# Altium schematic sheet STM32F429IGT6-V1.0.SchDoc(STM32F429IGT6-V1.0)
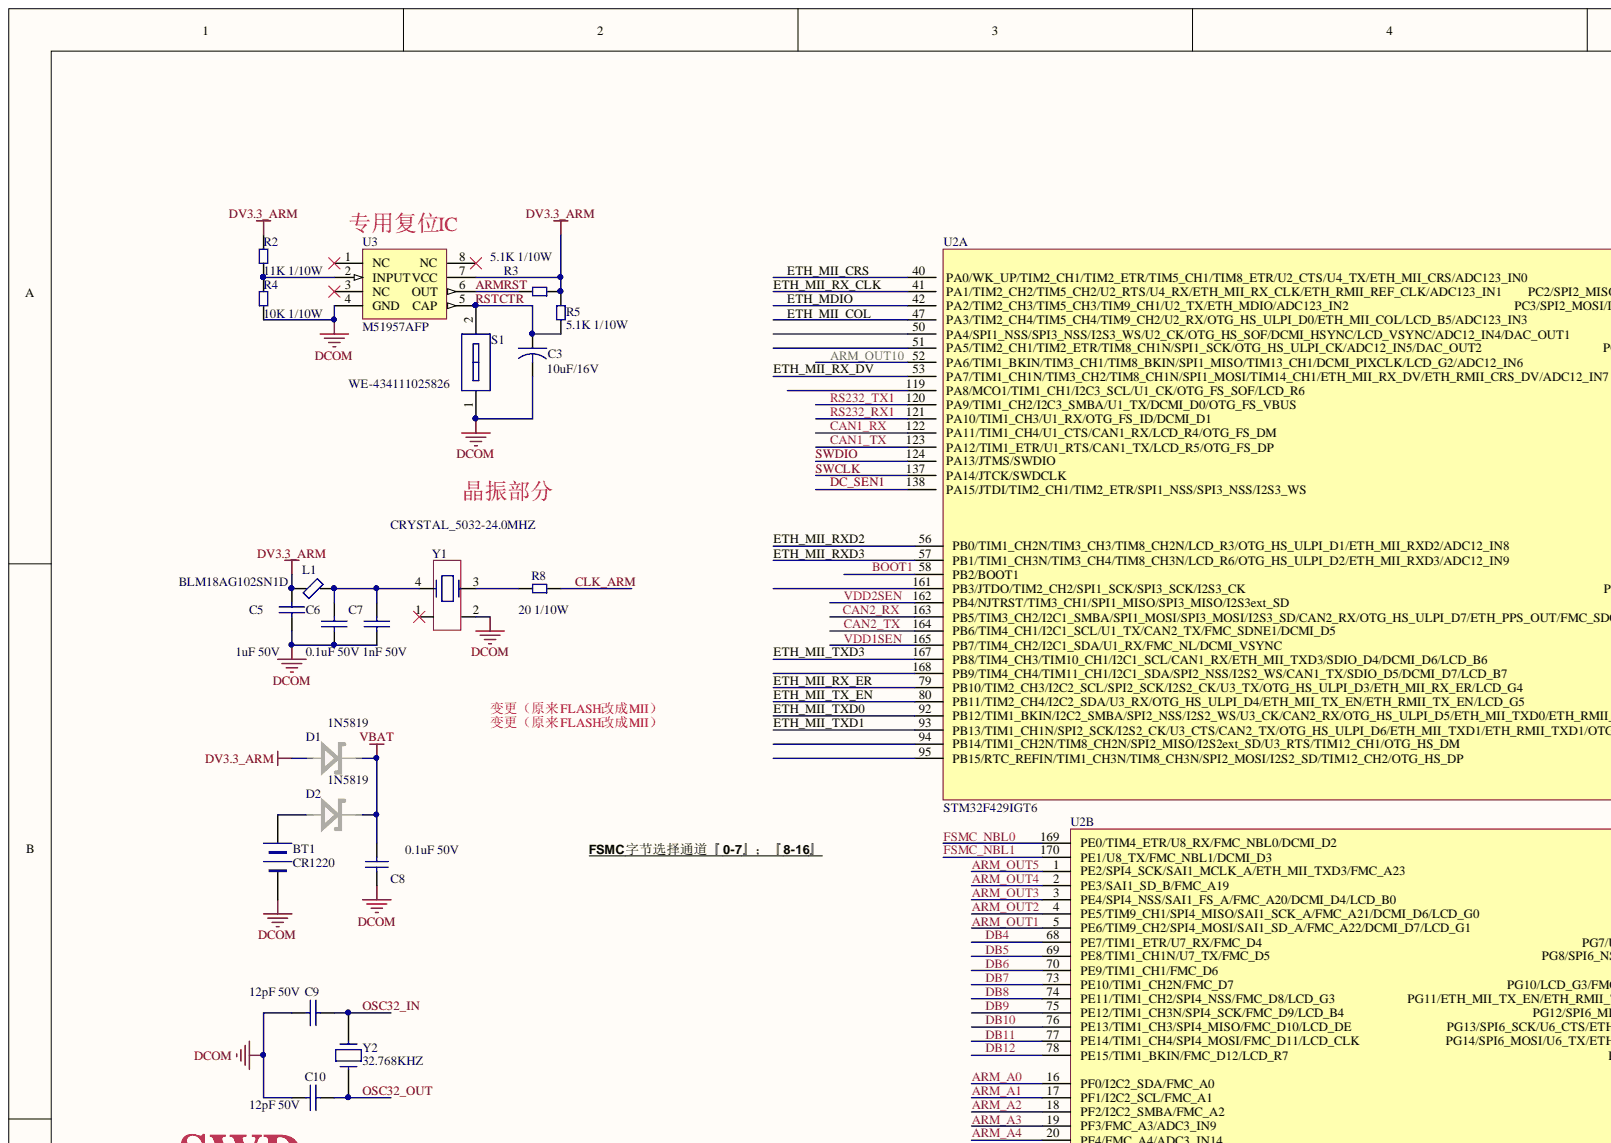
[diagram p0: STM32F429IGT6-V1.0.SchDoc, top left]
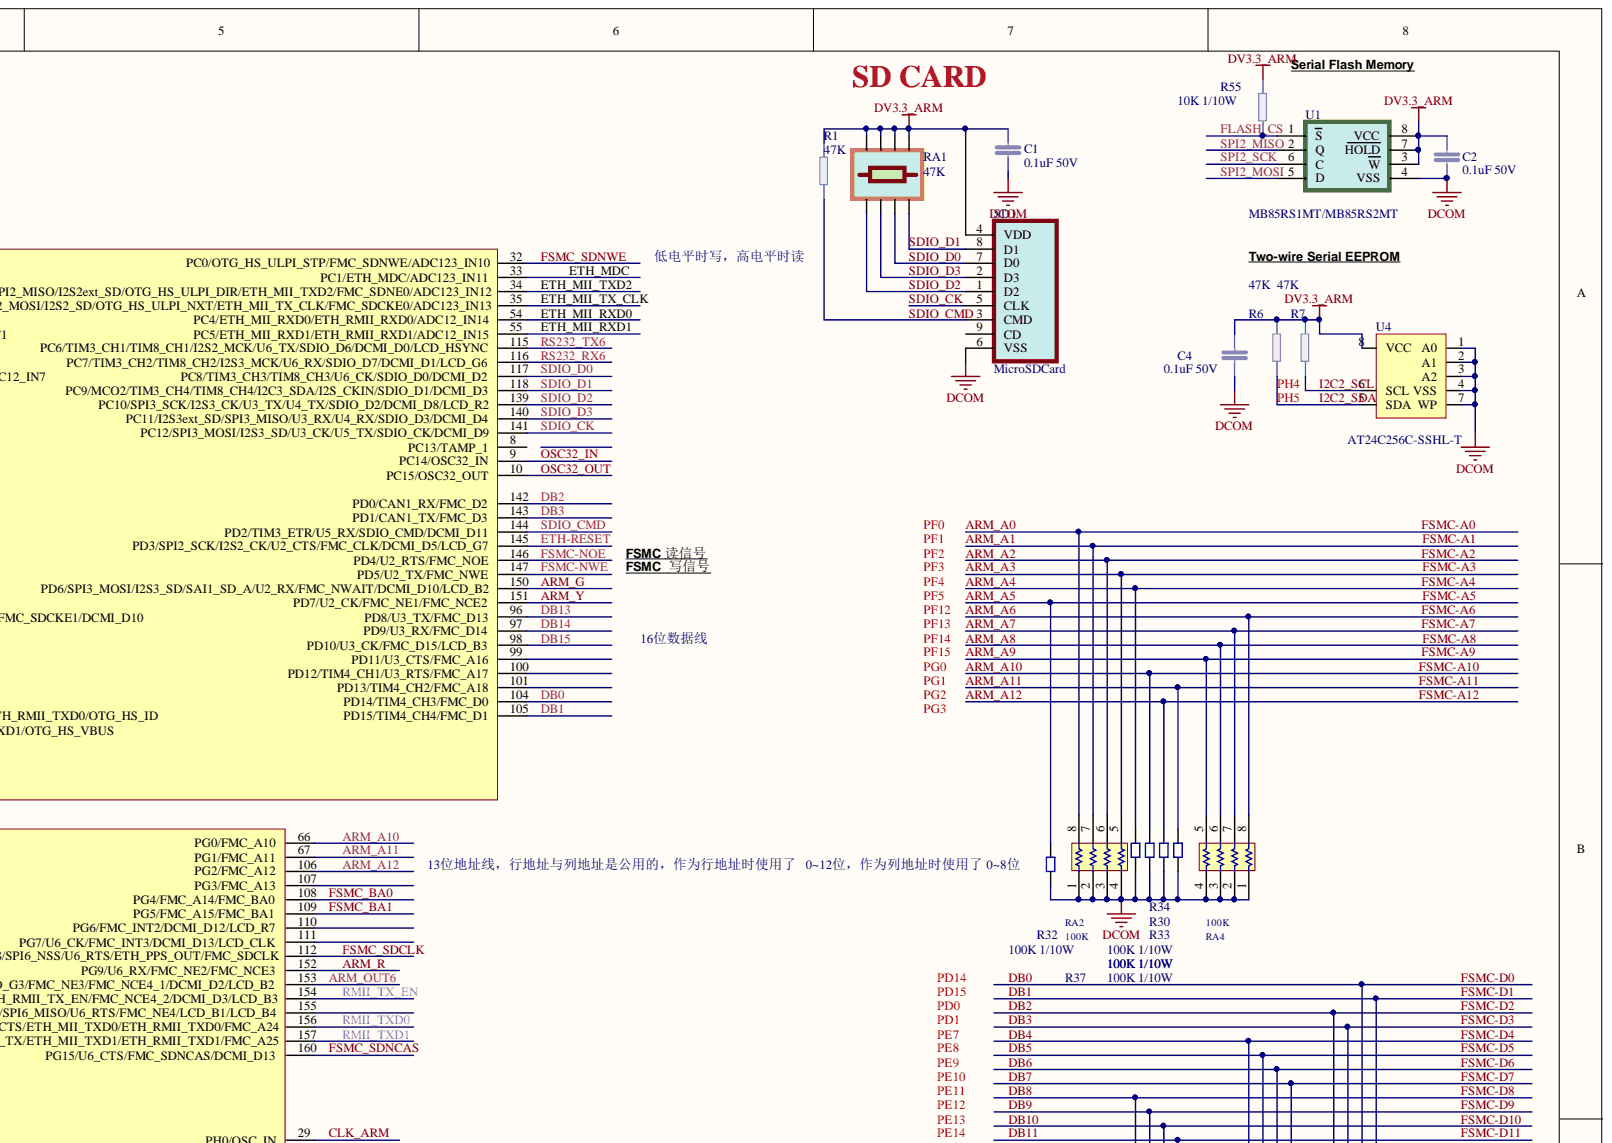
[diagram p1: STM32F429IGT6-V1.0.SchDoc, top right]
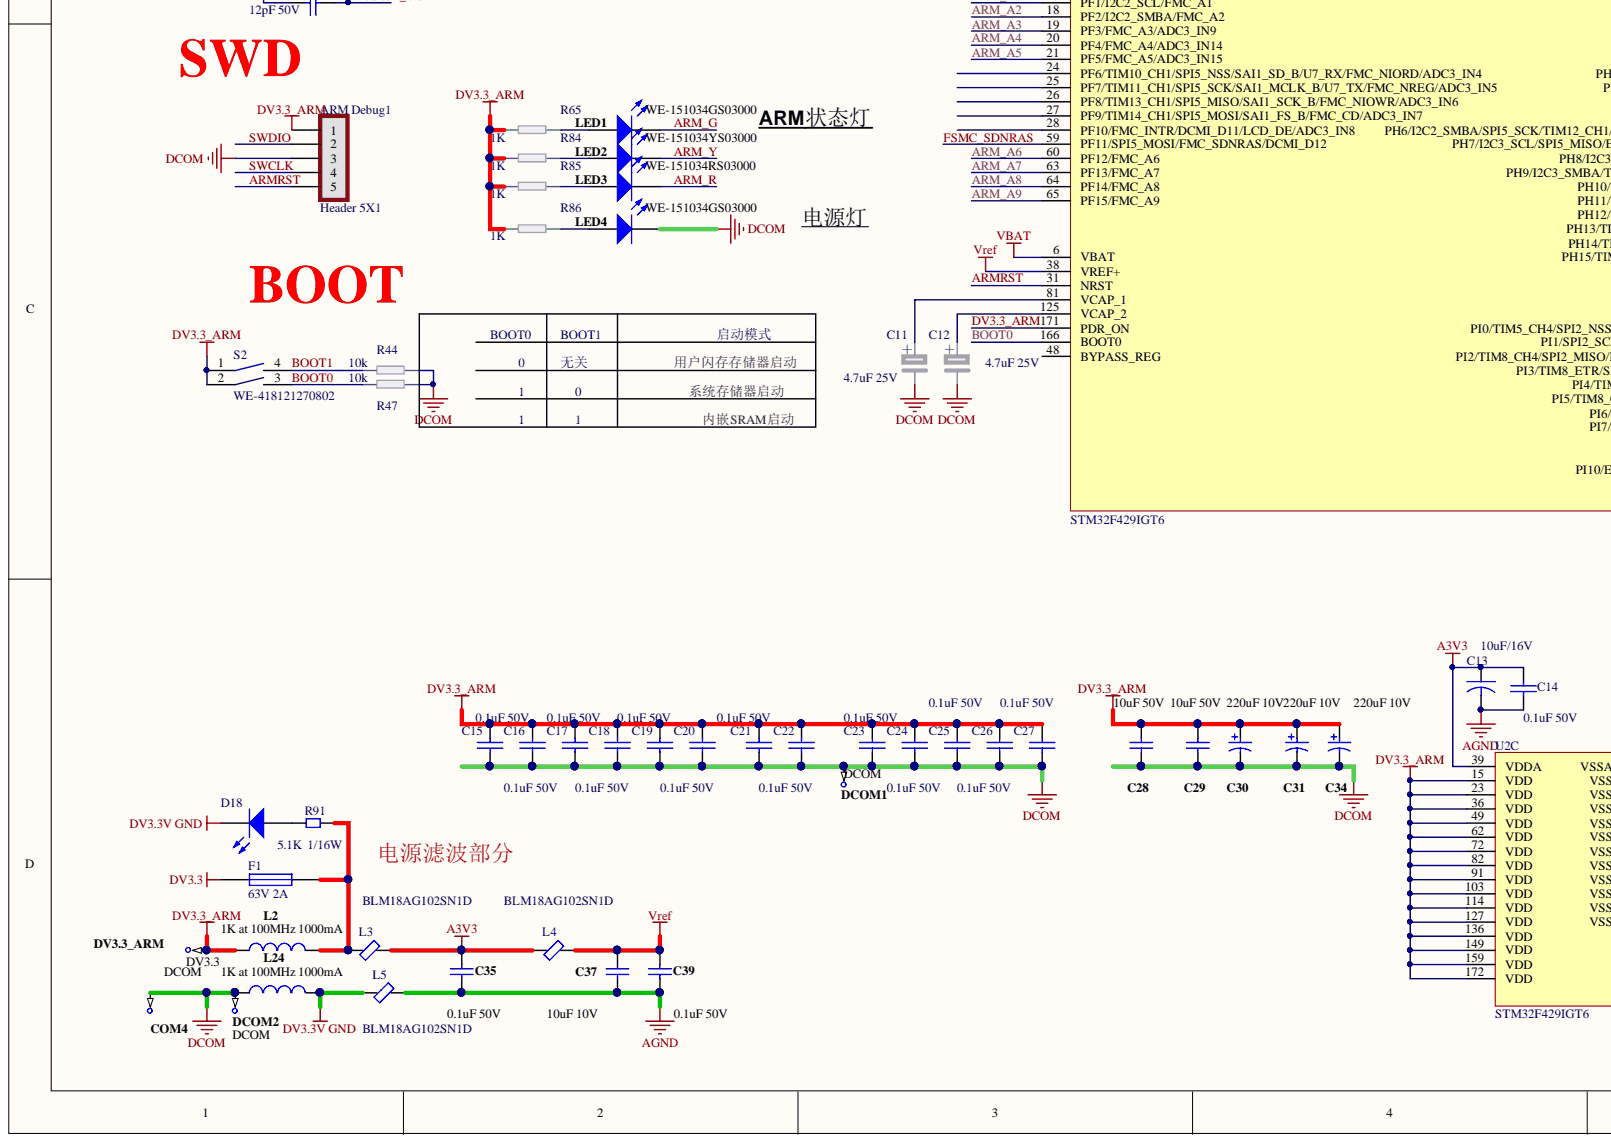
[diagram p2: STM32F429IGT6-V1.0.SchDoc, bottom left]
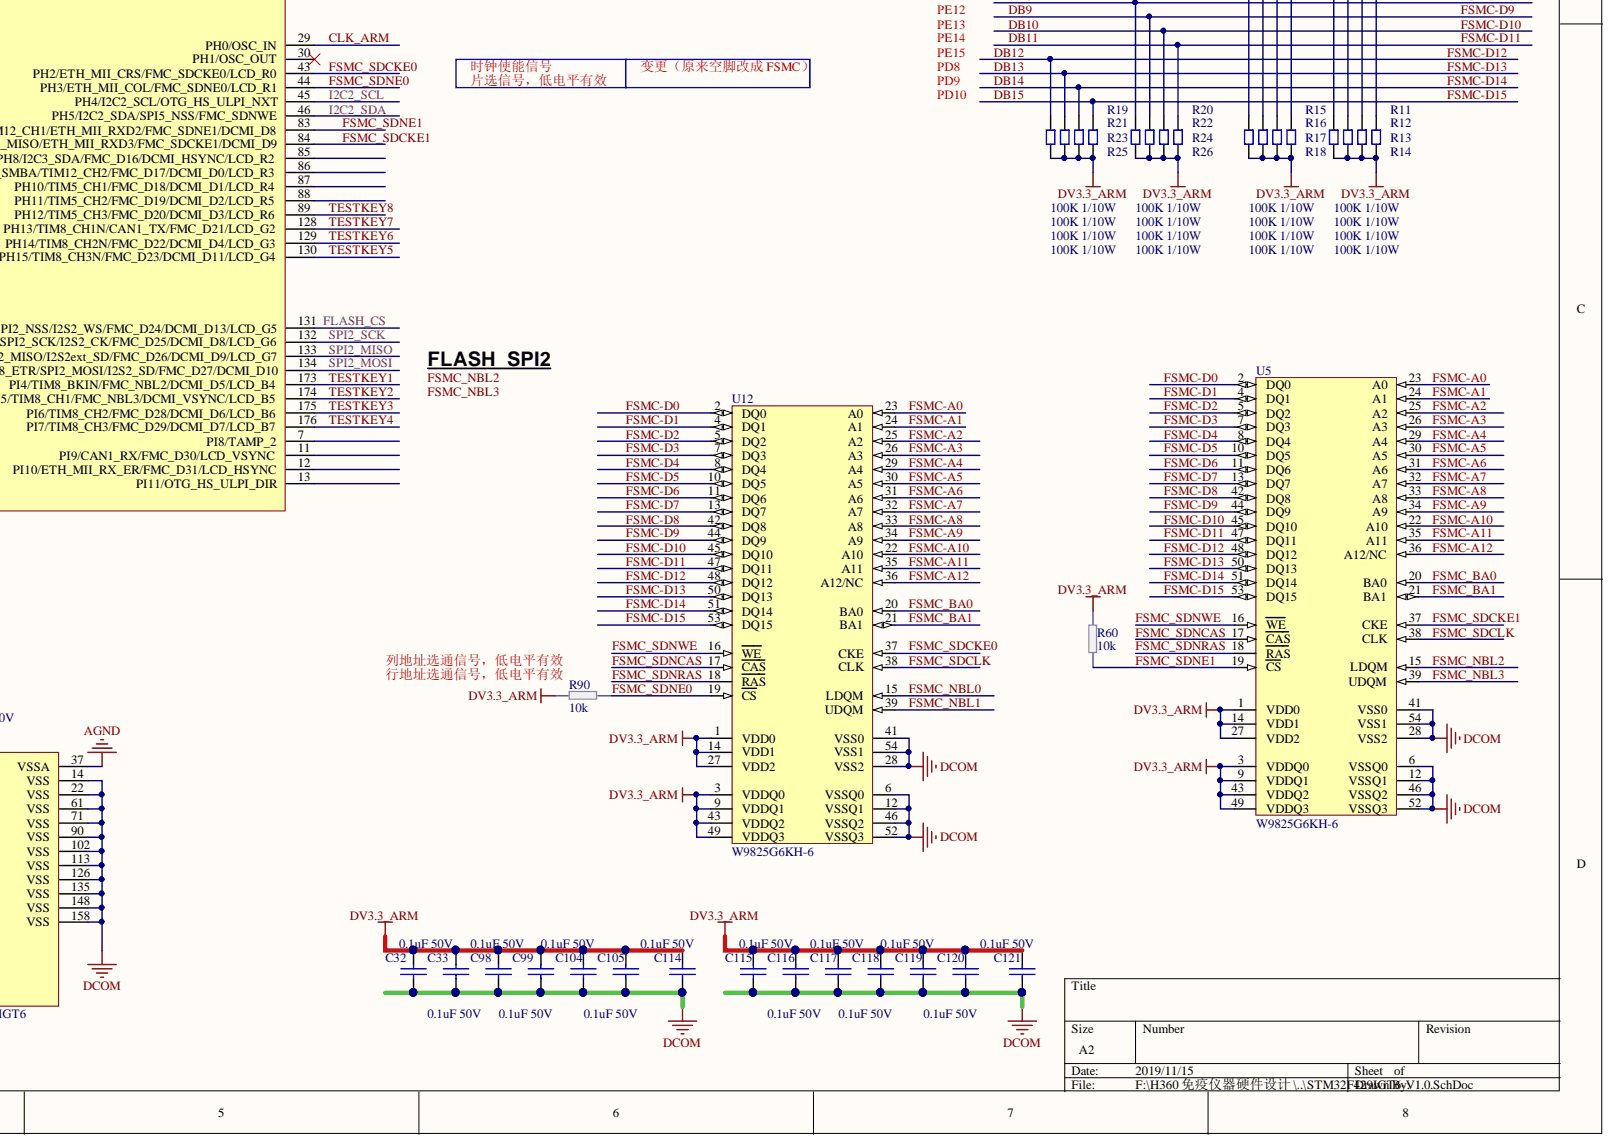
[diagram p3: STM32F429IGT6-V1.0.SchDoc, bottom right]

## Parts
ARM_Debug1  Header 5X1  [XH2.54-5P-SMD]
BT1  CR1220  [LI1220_1]
C1  0.1uF 50V  [C0603_104/50V]
C2  0.1uF 50V  [C0603_104/50V]
C3  10uF/16V  [CAPBCASE]
C4  0.1uF 50V  [0603P]
C5  1uF 50V  [C0603_105/50V]
C6  0.1uF 50V  [C0603_104/50V]
C7  1nF 50V  [C0603_102/50V]
C8  0.1uF 50V  [C0805_104/50V]
C9  12pF 50V  [C0603_120/50V]
C10  12pF 50V  [C0603_120/50V]
C11  4.7uF 25V  [TAN-A]
C12  4.7uF 25V  [TAN-A]
C13  10uF/16V  [CAPBCASE_106/16V]
C14  0.1uF 50V  [C0603_104/50V]
C15  0.1uF 50V  value 0.1uF  [C0603_104/50V]
C16  0.1uF 50V  value 0.1uF  [C0603_104/50V]
C17  0.1uF 50V  value 0.1uF  [C0603_104/50V]
C18  0.1uF 50V  value 0.1uF  [C0603_104/50V]
C19  0.1uF 50V  value 0.1uF  [C0603_104/50V]
C20  0.1uF 50V  value 0.1uF  [C0603_104/50V]
C21  0.1uF 50V  value 0.1uF  [C0603_104/50V]
C22  0.1uF 50V  value 0.1uF  [C0603_104/50V]
C23  0.1uF 50V  value 0.1uF  [C0603_104/50V]
C24  0.1uF 50V  value 0.1uF  [C0603_104/50V]
C25  0.1uF 50V  value 0.1uF  [C0603_104/50V]
C26  0.1uF 50V  value 0.1uF  [C0603_104/50V]
C27  0.1uF 50V  value 0.1uF  [C0603_104/50V]
C28  10uF 50V  [C0805_106/50V]
C29  10uF 50V  [C0805_106/50V]
C30  220uF 10V  [CAPDCASE_E]
C31  220uF 10V  [CAPDCASE_E]
C32  0.1uF 50V  value 0.1uF  [C0603_104/50V]
C33  0.1uF 50V  value 0.1uF  [C0603_104/50V]
C34  220uF 10V  [CAPDCASE_E]
C35  0.1uF 50V  [C0603_104/50V]
C37  10uF 10V  [C0603_106/50V]
C39  0.1uF 50V  [C0603_104/50V]
C98  0.1uF 50V  value 0.1uF  [C0603_104/50V]
C99  0.1uF 50V  value 0.1uF  [C0603_104/50V]
C104  0.1uF 50V  value 0.1uF  [C0603_104/50V]
C105  0.1uF 50V  value 0.1uF  [C0603_104/50V]
C114  0.1uF 50V  value 0.1uF  [C0603_104/50V]
C115  0.1uF 50V  value 0.1uF  [C0603_104/50V]
C116  0.1uF 50V  value 0.1uF  [C0603_104/50V]
C117  0.1uF 50V  value 0.1uF  [C0603_104/50V]
C118  0.1uF 50V  value 0.1uF  [C0603_104/50V]
C119  0.1uF 50V  value 0.1uF  [C0603_104/50V]
C120  0.1uF 50V  value 0.1uF  [C0603_104/50V]
C121  0.1uF 50V  value 0.1uF  [C0603_104/50V]
COM4  DCOM  [TestPin]  (no BOM)
D1  1N5819  [SS34_DO124AC]
D2  1N5819  [SS34_DO124AC]
D18  LED2  [LED_0805]  (value not drawn)
DCOM1  DCOM  [TestPin]  (no BOM)
DCOM2  DCOM  [TestPin]  (no BOM)
DV3.3_ARM  DV3.3  [TestPin]  (no BOM)
F1  63V 2A  [F1206_1A/105V]
L1  BLM18AG102SN1D  [0603_BLM18AG102SN1D]
L2  1K at 100MHz 1000mA  [0805_BLM21BB050SN1]
L3  BLM18AG102SN1D  [0603_BLM18AG102SN1D]
L4  BLM18AG102SN1D  [0603_BLM18AG102SN1D]
L5  BLM18AG102SN1D  [0603_BLM18AG102SN1D]
L24  1K at 100MHz 1000mA  [0805_BLM21BB050SN1]
LED1  WE-151034GS03000  [LED_3MM]
LED2  WE-151034YS03000  [LED_3MM]
LED3  WE-151034RS03000  [LED_3MM]
LED4  WE-151034GS03000  [LED_3MM]
R1  47K  [RES0603_473]
R2  11K 1/10W  [res0603_113]
R3  5.1K 1/10W  [res0603_512]
R4  10K 1/10W  [res0603_103]
R5  5.1K 1/10W  [res0603_512]
R6  47K  [RES0603_473]
R7  47K  [RES0603_473]
R8  20 1/10W  [res0603_200]
R11  100K 1/10W  [RES0603_100]
R12  100K 1/10W  [RES0603_100]
R13  100K 1/10W  [RES0603_100]
R14  100K 1/10W  [RES0603_100]
R15  100K 1/10W  [RES0603_100]
R16  100K 1/10W  [RES0603_100]
R17  100K 1/10W  [RES0603_100]
R18  100K 1/10W  [RES0603_100]
R19  100K 1/10W  [RES0603_100]
R20  100K 1/10W  [RES0603_100]
R21  100K 1/10W  [RES0603_100]
R22  100K 1/10W  [RES0603_100]
R23  100K 1/10W  [RES0603_100]
R24  100K 1/10W  [RES0603_100]
R25  100K 1/10W  [RES0603_100]
R26  100K 1/10W  [RES0603_100]
R30  100K 1/10W  [RES0603_100]
R32  100K 1/10W  [RES0603_100]
R33  100K 1/10W  [RES0603_100]
R34  100K 1/10W  [RES0603_100]
R37  100K 1/10W  [RES0603_100]
R44  10k  [RES0603_104]
R47  10k  [RES0603_104]
R55  10K 1/10W  [res0603_103]
R60  10k  [res0603_103]
R65  1K  [RES0603_102]
R84  1K  [RES0603_102]
R85  1K  [RES0603_102]
R86  1K  [RES0603_102]
R90  10k  [res0603_103]
R91  5.1K  1/16W  [res0603_512]
RA1  47K  [ra_yc164_102]
RA2  100K  [ra_yc164_104]
RA4  100K  [ra_yc164_104]
S1  WE-434111025826  [KEY735SO]
S2  WE-418121270802  [WS-DISV-2.54MM-2PIN_418121270802]
SD1  MicroSDCard  [microsdcard]
U1  MB85RS1MT/MB85RS2MT  [MB85RS2MT_SOP8W]
U2  STM32F429IGT6  [LQFP-176_STM32F407IGT6-176]  (parts A,B,C)
U3  M51957AFP  [SO8]
U4  AT24C256C-SSHL-T  [SOIC8_AT24C08]
U5  W9825G6KH-6  [TSOP-54]
U12  W9825G6KH-6  [TSOP-54]
Y1  CRYSTAL_5032-24.0MHZ  [CR-5032_24MHZ]
Y2  32.768KHZ  [CR_3215_32768]

## Nets
A3V3: C13.1 C14.2 C35.1 L3.2 L4.1 U2.39(VDDA)
AGND: C13.2 C14.1 C35.2 C37.2 C39.2 L5.2 U2.37(VSSA)
DCOM: ARM_Debug1.3 BT1.2 C1.1 C2.2 C3.2 C4.2 C5.2 C6.2 C7.2 C8.2 C9.2 C10.2 C11.2 C12.2 C15.2 C16.2 C17.2 C18.2 C19.2 C20.2 C21.2 C22.2 C23.2 C24.2 C25.2 C26.2 C27.2 C28.2 C29.2 C30.2 C31.2 C32.2 C33.2 C34.2 C98.2 C99.2 C104.2 C105.2 C114.2 C115.2 C116.2 C117.2 C118.2 C119.2 C120.2 C121.2 COM4.1 DCOM1.1 DCOM2.1 L24.1 LED4.2 R4.1 R30.2 R32.2 R33.2 R34.2 R37.2 R44.2 R47.2 RA2.1 RA2.2 RA2.3 RA2.4 RA4.1 RA4.2 RA4.3 RA4.4 S1.1 SD1.6(VSS) U1.4(VSS) U2.14(VSS) U2.22(VSS) U2.61(VSS) U2.71(VSS) U2.90(VSS) U2.102(VSS) U2.113(VSS) U2.126(VSS) U2.135(VSS) U2.148(VSS) U2.158(VSS) U3.4(GND) U4.1(A0) U4.2(A1) U4.3(A2) U4.4(VSS) U4.7(WP) U5.6(VSSQ0) U5.12(VSSQ1) U5.28(VSS2) U5.41(VSS0) U5.46(VSSQ2) U5.52(VSSQ3) U5.54(VSS1) U12.6(VSSQ0) U12.12(VSSQ1) U12.28(VSS2) U12.41(VSS0) U12.46(VSSQ2) U12.52(VSSQ3) U12.54(VSS1) Y1.2
DV3.3: F1.2
DV3.3V GND: D18.2 L5.1 L24.2
VBAT: C8.1 D1.2 D2.2 U2.6(VBAT)
VREF: C37.1 C39.1 L4.2 U2.38(VREF+)
ARM_A0: RA2.8 U2.16(PF0/I2C2_SDA/FMC_A0) U5.23(A0) U12.23(A0)
ARM_A1: RA2.7 U2.17(PF1/I2C2_SCL/FMC_A1) U5.24(A1) U12.24(A1)
ARM_A2: RA2.6 U2.18(PF2/I2C2_SMBA/FMC_A2) U5.25(A2) U12.25(A2)
ARM_A3: RA2.5 U2.19(PF3/FMC_A3/ADC3_IN9) U5.26(A3) U12.26(A3)
ARM_A4: R37.1 U2.20(PF4/FMC_A4/ADC3_IN14) U5.29(A4) U12.29(A4)
ARM_A5: R32.1 U2.21(PF5/FMC_A5/ADC3_IN15) U5.30(A5) U12.30(A5)
ARM_A6: RA4.8 U2.60(PF12/FMC_A6) U5.31(A6) U12.31(A6)
ARM_A7: RA4.7 U2.63(PF13/FMC_A7) U5.32(A7) U12.32(A7)
ARM_A8: RA4.6 U2.64(PF14/FMC_A8) U5.33(A8) U12.33(A8)
ARM_A9: RA4.5 U2.65(PF15/FMC_A9) U5.34(A9) U12.34(A9)
ARM_A10: R34.1 U2.66(PG0/FMC_A10) U5.22(A10) U12.22(A10)
ARM_A11: R30.1 U2.67(PG1/FMC_A11) U5.35(A11) U12.35(A11)
ARM_A12: R33.1 U2.106(PG2/FMC_A12) U5.36(A12/NC) U12.36(A12/NC)
ARM_G: LED1.2 U2.150(PD6/SPI3_MOSI/I2S3_SD/SAI1_SD_A/U2_RX/FMC_NWAIT/DCMI_D10/LCD_B2)
ARM_OUT1: U2.5(PE6/TIM9_CH2/SPI4_MOSI/SAI1_SD_A/FMC_A22/DCMI_D7/LCD_G1)
ARM_OUT2: U2.4(PE5/TIM9_CH1/SPI4_MISO/SAI1_SCK_A/FMC_A21/DCMI_D6/LCD_G0)
ARM_OUT3: U2.3(PE4/SPI4_NSS/SAI1_FS_A/FMC_A20/DCMI_D4/LCD_B0)
ARM_OUT4: U2.2(PE3/SAI1_SD_B/FMC_A19)
ARM_OUT5: U2.1(PE2/SPI4_SCK/SAI1_MCLK_A/ETH_MII_TXD3/FMC_A23)
ARM_OUT6: U2.153(PG10/LCD_G3/FMC_NE3/FMC_NCE4_1/DCMI_D2/LCD_B2)
ARM_OUT10: U2.52(PA6/TIM1_BKIN/TIM3_CH1/TIM8_BKIN/SPI1_MISO/TIM13_CH1/DCMI_PIXCLK/LCD_G2/ADC12_IN6)
ARM_R: LED3.2 U2.152(PG9/U6_RX/FMC_NE2/FMC_NCE3)
ARM_Y: LED2.2 U2.151(PD7/U2_CK/FMC_NE1/FMC_NCE2)
ARMRST: ARM_Debug1.5 R3.2 U2.31(NRST) U3.6(OUT)
BOOT0: R47.1 S2.3 U2.166(BOOT0)
BOOT1: R44.1 S2.4 U2.58(PB2/BOOT1)
CAN1_RX: U2.122(PA11/TIM1_CH4/U1_CTS/CAN1_RX/LCD_R4/OTG_FS_DM)
CAN1_TX: U2.123(PA12/TIM1_ETR/U1_RTS/CAN1_TX/LCD_R5/OTG_FS_DP)
CAN2_RX: U2.163(PB5/TIM3_CH2/I2C1_SMBA/SPI1_MOSI/SPI3_MOSI/I2S3_SD/CAN2_RX/OTG_HS_ULPI_D7/ETH_PPS_OUT/FMC_SDCKE1/DCMI_D10)
CAN2_TX: U2.164(PB6/TIM4_CH1/I2C1_SCL/U1_TX/CAN2_TX/FMC_SDNE1/DCMI_D5)
CLK_ARM: R8.1 U2.29(PH0/OSC_IN)
DB0: R11.1 U2.104(PD14/TIM4_CH3/FMC_D0) U5.2(DQ0) U12.2(DQ0)
DB1: R12.1 U2.105(PD15/TIM4_CH4/FMC_D1) U5.4(DQ1) U12.4(DQ1)
DB2: R13.1 U2.142(PD0/CAN1_RX/FMC_D2) U5.5(DQ2) U12.5(DQ2)
DB3: R14.1 U2.143(PD1/CAN1_TX/FMC_D3) U5.7(DQ3) U12.7(DQ3)
DB4: R17.1 U2.68(PE7/TIM1_ETR/U7_RX/FMC_D4) U5.8(DQ4) U12.8(DQ4)
DB5: R18.1 U2.69(PE8/TIM1_CH1N/U7_TX/FMC_D5) U5.10(DQ5) U12.10(DQ5)
DB6: R15.1 U2.70(PE9/TIM1_CH1/FMC_D6) U5.11(DQ6) U12.11(DQ6)
DB7: R16.1 U2.73(PE10/TIM1_CH2N/FMC_D7) U5.13(DQ7) U12.13(DQ7)
DB8: R24.1 U2.74(PE11/TIM1_CH2/SPI4_NSS/FMC_D8/LCD_G3) U5.42(DQ8) U12.42(DQ8)
DB9: R26.1 U2.75(PE12/TIM1_CH3N/SPI4_SCK/FMC_D9/LCD_B4) U5.44(DQ9) U12.44(DQ9)
DB10: R20.1 U2.76(PE13/TIM1_CH3/SPI4_MISO/FMC_D10/LCD_DE) U5.45(DQ10) U12.45(DQ10)
DB11: R22.1 U2.77(PE14/TIM1_CH4/SPI4_MOSI/FMC_D11/LCD_CLK) U5.47(DQ11) U12.47(DQ11)
DB12: R23.1 U2.78(PE15/TIM1_BKIN/FMC_D12/LCD_R7) U5.48(DQ12) U12.48(DQ12)
DB13: R25.1 U2.96(PD8/U3_TX/FMC_D13) U5.50(DQ13) U12.50(DQ13)
DB14: R19.1 U2.97(PD9/U3_RX/FMC_D14) U5.51(DQ14) U12.51(DQ14)
DB15: R21.1 U2.98(PD10/U3_CK/FMC_D15/LCD_B3) U5.53(DQ15) U12.53(DQ15)
DC_SEN1: U2.138(PA15/JTDI/TIM2_CH1/TIM2_ETR/SPI1_NSS/SPI3_NSS/I2S3_WS)
DV3.3_ARM: ARM_Debug1.1 C1.2 C2.1 C4.1 C5.1 C15.1 C16.1 C17.1 C18.1 C19.1 C20.1 C21.1 C22.1 C23.1 C24.1 C25.1 C26.1 C27.1 C28.1 C29.1 C30.1 C31.1 C32.1 C33.1 C34.1 C98.1 C99.1 C104.1 C105.1 C114.1 C115.1 C116.1 C117.1 C118.1 C119.1 C120.1 C121.1 D1.1 DV3.3_ARM.1 L1.1 L2.1 R1.2 R2.2 R3.1 R5.1 R6.2 R7.2 R11.2 R12.2 R13.2 R14.2 R15.2 R16.2 R17.2 R18.2 R19.2 R20.2 R21.2 R22.2 R23.2 R24.2 R25.2 R26.2 R55.2 R60.1 R65.1 R84.1 R85.1 R86.1 R90.2 RA1.5 RA1.6 RA1.7 RA1.8 S2.1 S2.2 SD1.4(VDD) U1.3(W) U1.7(HOLD) U1.8(VCC) U2.15(VDD) U2.23(VDD) U2.36(VDD) U2.49(VDD) U2.62(VDD) U2.72(VDD) U2.82(VDD) U2.91(VDD) U2.103(VDD) U2.114(VDD) U2.127(VDD) U2.136(VDD) U2.149(VDD) U2.159(VDD) U2.171(PDR_ON) U2.172(VDD) U3.7(VCC) U4.8(VCC) U5.1(VDD0) U5.3(VDDQ0) U5.9(VDDQ1) U5.14(VDD1) U5.27(VDD2) U5.43(VDDQ2) U5.49(VDDQ3) U12.1(VDD0) U12.3(VDDQ0) U12.9(VDDQ1) U12.14(VDD1) U12.27(VDD2) U12.43(VDDQ2) U12.49(VDDQ3)
ETH-RESET: U2.145(PD3/SPI2_SCK/I2S2_CK/U2_CTS/FMC_CLK/DCMI_D5/LCD_G7)
ETH_MDC: U2.33(PC1/ETH_MDC/ADC123_IN11)
ETH_MDIO: U2.42(PA2/TIM2_CH3/TIM5_CH3/TIM9_CH1/U2_TX/ETH_MDIO/ADC123_IN2)
ETH_MII_COL: U2.47(PA3/TIM2_CH4/TIM5_CH4/TIM9_CH2/U2_RX/OTG_HS_ULPI_D0/ETH_MII_COL/LCD_B5/ADC123_IN3)
ETH_MII_CRS: U2.40(PA0/WK_UP/TIM2_CH1/TIM2_ETR/TIM5_CH1/TIM8_ETR/U2_CTS/U4_TX/ETH_MII_CRS/ADC123_IN0)
ETH_MII_RX_CLK: U2.41(PA1/TIM2_CH2/TIM5_CH2/U2_RTS/U4_RX/ETH_MII_RX_CLK/ETH_RMII_REF_CLK/ADC123_IN1)
ETH_MII_RX_DV: U2.53(PA7/TIM1_CH1N/TIM3_CH2/TIM8_CH1N/SPI1_MOSI/TIM14_CH1/ETH_MII_RX_DV/ETH_RMII_CRS_DV/ADC12_IN7)
ETH_MII_RX_ER: U2.79(PB10/TIM2_CH3/I2C2_SCL/SPI2_SCK/I2S2_CK/U3_TX/OTG_HS_ULPI_D3/ETH_MII_RX_ER/LCD_G4)
ETH_MII_RXD0: U2.54(PC4/ETH_MII_RXD0/ETH_RMII_RXD0/ADC12_IN14)
ETH_MII_RXD1: U2.55(PC5/ETH_MII_RXD1/ETH_RMII_RXD1/ADC12_IN15)
ETH_MII_RXD2: U2.56(PB0/TIM1_CH2N/TIM3_CH3/TIM8_CH2N/LCD_R3/OTG_HS_ULPI_D1/ETH_MII_RXD2/ADC12_IN8)
ETH_MII_RXD3: U2.57(PB1/TIM1_CH3N/TIM3_CH4/TIM8_CH3N/LCD_R6/OTG_HS_ULPI_D2/ETH_MII_RXD3/ADC12_IN9)
ETH_MII_TX_CLK: U2.35(PC3/SPI2_MOSI/I2S2_SD/OTG_HS_ULPI_NXT/ETH_MII_TX_CLK/FMC_SDCKE0/ADC123_IN13)
ETH_MII_TX_EN: U2.80(PB11/TIM2_CH4/I2C2_SDA/U3_RX/OTG_HS_ULPI_D4/ETH_MII_TX_EN/ETH_RMII_TX_EN/LCD_G5)
ETH_MII_TXD0: U2.92(PB12/TIM1_BKIN/I2C2_SMBA/SPI2_NSS/I2S2_WS/U3_CK/CAN2_RX/OTG_HS_ULPI_D5/ETH_MII_TXD0/ETH_RMII_TXD0/OTG_HS_ID)
ETH_MII_TXD1: U2.93(PB13/TIM1_CH1N/SPI2_SCK/I2S2_CK/U3_CTS/CAN2_TX/OTG_HS_ULPI_D6/ETH_MII_TXD1/ETH_RMII_TXD1/OTG_HS_VBUS)
ETH_MII_TXD2: U2.34(PC2/SPI2_MISO/I2S2ext_SD/OTG_HS_ULPI_DIR/ETH_MII_TXD2/FMC_SDNE0/ADC123_IN12)
ETH_MII_TXD3: U2.167(PB8/TIM4_CH3/TIM10_CH1/I2C1_SCL/CAN1_RX/ETH_MII_TXD3/SDIO_D4/DCMI_D6/LCD_B6)
FLASH_CS: R55.1 U1.1(S) U2.131(PI0/TIM5_CH4/SPI2_NSS/I2S2_WS/FMC_D24/DCMI_D13/LCD_G5)
FSMC-NOE: U2.146(PD4/U2_RTS/FMC_NOE)
FSMC-NWE: U2.147(PD5/U2_TX/FMC_NWE)
FSMC_BA0: U2.108(PG4/FMC_A14/FMC_BA0) U5.20(BA0) U12.20(BA0)
FSMC_BA1: U2.109(PG5/FMC_A15/FMC_BA1) U5.21(BA1) U12.21(BA1)
FSMC_NBL0: U2.169(PE0/TIM4_ETR/U8_RX/FMC_NBL0/DCMI_D2) U12.15(LDQM)
FSMC_NBL1: U2.170(PE1/U8_TX/FMC_NBL1/DCMI_D3) U12.39(UDQM)
FSMC_NBL2: U5.15(LDQM)
FSMC_NBL3: U5.39(UDQM)
FSMC_SDCKE0: U2.43(PH2/ETH_MII_CRS/FMC_SDCKE0/LCD_R0) U12.37(CKE)
FSMC_SDCKE1: U2.84(PH7/I2C3_SCL/SPI5_MISO/ETH_MII_RXD3/FMC_SDCKE1/DCMI_D9) U5.37(CKE)
FSMC_SDCLK: U2.112(PG8/SPI6_NSS/U6_RTS/ETH_PPS_OUT/FMC_SDCLK) U5.38(CLK) U12.38(CLK)
FSMC_SDNCAS: U2.160(PG15/U6_CTS/FMC_SDNCAS/DCMI_D13) U5.17(CAS) U12.17(CAS)
FSMC_SDNE0: R90.1 U2.44(PH3/ETH_MII_COL/FMC_SDNE0/LCD_R1) U12.19(CS)
FSMC_SDNE1: R60.2 U2.83(PH6/I2C2_SMBA/SPI5_SCK/TIM12_CH1/ETH_MII_RXD2/FMC_SDNE1/DCMI_D8) U5.19(CS)
FSMC_SDNRAS: U2.59(PF11/SPI5_MOSI/FMC_SDNRAS/DCMI_D12) U5.18(RAS) U12.18(RAS)
FSMC_SDNWE: U2.32(PC0/OTG_HS_ULPI_STP/FMC_SDNWE/ADC123_IN10) U5.16(WE) U12.16(WE)
I2C2_SCL: R7.1 U2.45(PH4/I2C2_SCL/OTG_HS_ULPI_NXT) U4.6(SCL)
I2C2_SDA: R6.1 U2.46(PH5/I2C2_SDA/SPI5_NSS/FMC_SDNWE) U4.5(SDA)
OSC32_IN: C9.1 U2.9(PC14/OSC32_IN) Y2.2
OSC32_OUT: C10.1 U2.10(PC15/OSC32_OUT) Y2.1
RMII_TX_EN: U2.154(PG11/ETH_MII_TX_EN/ETH_RMII_TX_EN/FMC_NCE4_2/DCMI_D3/LCD_B3)
RMII_TXD0: U2.156(PG13/SPI6_SCK/U6_CTS/ETH_MII_TXD0/ETH_RMII_TXD0/FMC_A24)
RMII_TXD1: U2.157(PG14/SPI6_MOSI/U6_TX/ETH_MII_TXD1/ETH_RMII_TXD1/FMC_A25)
RS232_RX1: U2.121(PA10/TIM1_CH3/U1_RX/OTG_FS_ID/DCMI_D1)
RS232_RX6: U2.116(PC7/TIM3_CH2/TIM8_CH2/I2S3_MCK/U6_RX/SDIO_D7/DCMI_D1/LCD_G6)
RS232_TX1: U2.120(PA9/TIM1_CH2/I2C3_SMBA/U1_TX/DCMI_D0/OTG_FS_VBUS)
RS232_TX6: U2.115(PC6/TIM3_CH1/TIM8_CH1/I2S2_MCK/U6_TX/SDIO_D6/DCMI_D0/LCD_HSYNC)
RSTCTR: C3.1 R5.2 S1.2 U3.5(CAP)
SDIO_CK: SD1.5(CLK) U2.141(PC12/SPI3_MOSI/I2S3_SD/U3_CK/U5_TX/SDIO_CK/DCMI_D9)
SDIO_CMD: R1.1 SD1.3(CMD) U2.144(PD2/TIM3_ETR/U5_RX/SDIO_CMD/DCMI_D11)
SDIO_D0: RA1.2 SD1.7(D0) U2.117(PC8/TIM3_CH3/TIM8_CH3/U6_CK/SDIO_D0/DCMI_D2)
SDIO_D1: RA1.1 SD1.8(D1) U2.118(PC9/MCO2/TIM3_CH4/TIM8_CH4/I2C3_SDA/I2S_CKIN/SDIO_D1/DCMI_D3)
SDIO_D2: RA1.4 SD1.1(D2) U2.139(PC10/SPI3_SCK/I2S3_CK/U3_TX/U4_TX/SDIO_D2/DCMI_D8/LCD_R2)
SDIO_D3: RA1.3 SD1.2(D3) U2.140(PC11/I2S3ext_SD/SPI3_MISO/U3_RX/U4_RX/SDIO_D3/DCMI_D4)
SPI2_MISO: U1.2(Q) U2.133(PI2/TIM8_CH4/SPI2_MISO/I2S2ext_SD/FMC_D26/DCMI_D9/LCD_G7)
SPI2_MOSI: U1.5(D) U2.134(PI3/TIM8_ETR/SPI2_MOSI/I2S2_SD/FMC_D27/DCMI_D10)
SPI2_SCK: U1.6(C) U2.132(PI1/SPI2_SCK/I2S2_CK/FMC_D25/DCMI_D8/LCD_G6)
SWCLK: ARM_Debug1.4 U2.137(PA14/JTCK/SWDCLK)
SWDIO: ARM_Debug1.2 U2.124(PA13/JTMS/SWDIO)
TESTKEY1: U2.173(PI4/TIM8_BKIN/FMC_NBL2/DCMI_D5/LCD_B4)
TESTKEY2: U2.174(PI5/TIM8_CH1/FMC_NBL3/DCMI_VSYNC/LCD_B5)
TESTKEY3: U2.175(PI6/TIM8_CH2/FMC_D28/DCMI_D6/LCD_B6)
TESTKEY4: U2.176(PI7/TIM8_CH3/FMC_D29/DCMI_D7/LCD_B7)
TESTKEY5: U2.130(PH15/TIM8_CH3N/FMC_D23/DCMI_D11/LCD_G4)
TESTKEY6: U2.129(PH14/TIM8_CH2N/FMC_D22/DCMI_D4/LCD_G3)
TESTKEY7: U2.128(PH13/TIM8_CH1N/CAN1_TX/FMC_D21/LCD_G2)
TESTKEY8: U2.89(PH12/TIM5_CH3/FMC_D20/DCMI_D3/LCD_R6)
VDD1SEN: U2.165(PB7/TIM4_CH2/I2C1_SDA/U1_RX/FMC_NL/DCMI_VSYNC)
VDD2SEN: U2.162(PB4/NJTRST/TIM3_CH1/SPI1_MISO/SPI3_MISO/I2S3ext_SD)
NetBT1_1: BT1.1 D2.1
NetC6_1: C6.1 C7.1 L1.2 Y1.4
NetC11_1: C11.1 U2.81(VCAP_1)
NetC12_1: C12.1 U2.125(VCAP_2)
NetD18_1: D18.1 R91.2
NetF1_1: F1.1 L2.2 L3.1 R91.1
NetLED1_1: LED1.1 R65.2
NetLED2_1: LED2.1 R84.2
NetLED3_1: LED3.1 R85.2
NetLED4_1: LED4.1 R86.2
NetR2_1: R2.1 R4.2 U3.2(INPUT)
NetR8_2: R8.2 Y1.3
N00597:

Not connected: SD1 9(CD); U2 7(PI8/TAMP_2) 8(PC13/TAMP_1) 11(PI9/CAN1_RX/FMC_D30/LCD_VSYNC) 12(PI10/ETH_MII_RX_ER/FMC_D31/LCD_HSYNC) 13(PI11/OTG_HS_ULPI_DIR) 24(PF6/TIM10_CH1/SPI5_NSS/SAI1_SD_B/U7_RX/FMC_NIORD/ADC3_IN4) 25(PF7/TIM11_CH1/SPI5_SCK/SAI1_MCLK_B/U7_TX/FMC_NREG/ADC3_IN5) 26(PF8/TIM13_CH1/SPI5_MISO/SAI1_SCK_B/FMC_NIOWR/ADC3_IN6) 27(PF9/TIM14_CH1/SPI5_MOSI/SAI1_FS_B/FMC_CD/ADC3_IN7) 28(PF10/FMC_INTR/DCMI_D11/LCD_DE/ADC3_IN8) 30(PH1/OSC_OUT) 48(BYPASS_REG) 50(PA4/SPI1_NSS/SPI3_NSS/I2S3_WS/U2_CK/OTG_HS_SOF/DCMI_HSYNC/LCD_VSYNC/ADC12_IN4/DAC_OUT1) 51(PA5/TIM2_CH1/TIM2_ETR/TIM8_CH1N/SPI1_SCK/OTG_HS_ULPI_CK/ADC12_IN5/DAC_OUT2) 85(PH8/I2C3_SDA/FMC_D16/DCMI_HSYNC/LCD_R2) 86(PH9/I2C3_SMBA/TIM12_CH2/FMC_D17/DCMI_D0/LCD_R3) 87(PH10/TIM5_CH1/FMC_D18/DCMI_D1/LCD_R4) 88(PH11/TIM5_CH2/FMC_D19/DCMI_D2/LCD_R5) 94(PB14/TIM1_CH2N/TIM8_CH2N/SPI2_MISO/I2S2ext_SD/U3_RTS/TIM12_CH1/OTG_HS_DM) 95(PB15/RTC_REFIN/TIM1_CH3N/TIM8_CH3N/SPI2_MOSI/I2S2_SD/TIM12_CH2/OTG_HS_DP) 99(PD11/U3_CTS/FMC_A16) 100(PD12/TIM4_CH1/U3_RTS/FMC_A17) 101(PD13/TIM4_CH2/FMC_A18) 107(PG3/FMC_A13) 110(PG6/FMC_INT2/DCMI_D12/LCD_R7) 111(PG7/U6_CK/FMC_INT3/DCMI_D13/LCD_CLK) 119(PA8/MCO1/TIM1_CH1/I2C3_SCL/U1_CK/OTG_FS_SOF/LCD_R6) 155(PG12/SPI6_MISO/U6_RTS/FMC_NE4/LCD_B1/LCD_B4) 161(PB3/JTDO/TIM2_CH2/SPI1_SCK/SPI3_SCK/I2S3_CK) 168(PB9/TIM4_CH4/TIM11_CH1/I2C1_SDA/SPI2_NSS/I2S2_WS/CAN1_TX/SDIO_D5/DCMI_D7/LCD_B7); U3 1(NC) 3(NC) 8(NC); Y1 1

## Library data of the parts
ARM_Debug1 = HDR5X1: Component Kind: Standard; Description: Header 5X1; Library Name: 2017.4.24 MainBoard.SchLib
BT1 = BATTERY: Component Kind: Standard; Description: Battery; Library Name: 3DGeneral.SCHLIB
C1 C2 C4 = CAP: Capacitor, Ceramic, 0.1uF 10% 16V 0603  X7R; Component Kind: Standard; Library Name: 3DGeneral.SCHLIB
C3 = CAPACITOR: AVX  TAN Capacitor; Component Kind: Standard
C5-C7 = CAP: 'SAMSUNG  MULTILAYER  CERAMIC 5%  0603 X7R; Component Kind: Standard
C8 = Cap Semi: Capacitor (Semiconductor SIM Model); Value: 105; Component Kind: Standard; LatestRevisionDate: 29-May-2009; LatestRevisionNote: IPC-7351 Footprint Added.; Library Name: Miscellaneous Devices.IntLib; PackageDescription: Chip Capacitor; PackageReference: C1206; Published: 8-Jun-2000; Signal Integrity: Cap Semi; Simulation: CAP; Publisher: Altium Limited
C9 C10 = CAP: Capacitor, Ceramic, 10nF 10% 16V 0603 X7R; Component Kind: Standard
C11 C12 = CAPACITOR: Component Kind: Standard; Description: Capacitor; Library Name: 3DGeneral.SCHLIB
C13 = CAPACITOR: AVX TAN B CASE; Component Kind: Standard
C14 = CAP: Capacitor; Component Kind: Standard
C15-C27 C32 C33 C98 C99 C104 C105 C114-C121 = CAP: Capacitor, Ceramic, 0.1uF 10% 50V 0603; Manufacturer: Kemet; MFG Part Number: C0603C104K5RACTU; Component Kind: Standard
C28 C29 = D-101-0087: Capacitor, Ceramic, 10uF 10% 10V 0805 (2012) X5R; Polarity: Non-Polarized; Capacitance: 10 uF; Category: Capacitor; Component Kind: Standard; Dielectric: X5R; Library Name: AltiumDesignLibrary.DesignLib; Mounting Technology: Surface Mount; Rated Voltage: 10 V; Status: Unreleased; Technology: None; Tolerance: 10 %
C30 C31 C34 = D-101-0088: AVX Capacitor, Tantalum, 220uF 10% 10V MCCT-D (7343-31); Polarity: Polarized; Capacitance: 220 uF; Category: Capacitor; Component Kind: Standard; Library Name: AltiumDesignLibrary.DesignLib; Mounting Technology: Surface Mount; PCB3D: GCap; Rated Voltage: 10 V; Status: Unreleased; Technology: Tantalum; Tolerance: 10 %
C35 = D-101-0080: Capacitor, Ceramic, 0.1uF 10% 16V 0603  X7R; Polarity: Non-Polarized; Capacitance: 0.1 uF; Category: Capacitor; Component Kind: Standard; Dielectric: X7R; Library Name: AltiumDesignLibrary.DesignLib; Mounting Technology: Surface Mount; Rated Voltage: 16 V; Status: Production; Technology: Ceramic; Tolerance: 10 %
C37 = D-101-0087: Capacitor, Ceramic, 10uF 10% 10V 0603  X5R; Polarity: Non-Polarized; Capacitance: 10 uF; Category: Capacitor; Component Kind: Standard; Dielectric: X5R; Library Name: AltiumDesignLibrary.DesignLib; Mounting Technology: Surface Mount; Rated Voltage: 10 V; Status: Unreleased; Technology: None; Tolerance: 10 %
C39 = D-101-0080: Capacitor, Ceramic, 0.1uF 10% 50V 0603 X7R; Polarity: Non-Polarized; Rated Voltage: 16 V; Capacitance: 0.1 uF; Category: Capacitor; Component Kind: Standard; Dielectric: X7R; Library Name: AltiumDesignLibrary.DesignLib; Mounting Technology: Surface Mount; Status: Production; Technology: Ceramic; Tolerance: 10 %
COM4 DCOM1 DCOM2 DV3.3_ARM = D-006-0000: Test Point, PCB Mount with Silkscreen Box; Category: Test-Point; Library Name: AltiumDesignLibrary.DesignLib; Status: Production
D1 D2 = 1N5817: Component Kind: Standard; Library Name: 3DGeneral.SCHLIB
D18 = LED2: Typical  GREEN,  GaAs LED; Component Kind: Standard; LatestRevisionDate: 17-Jul-2002; LatestRevisionNote: Re-released for DXP Platform.; Library Name: Miscellaneous Devices.IntLib; PackageDescription: SM LED; 2 Flat Leads; PackageReference: 3.2X1.6X1.1; PackageVersion: Feb-2002; Published: 8-Jun-2000; Publisher: Altium Limited
F1 = FUSE1: Littelfuse Surface Mount Fuses 501Series-Hight Current 1206 Fast-ACT; Component Kind: Standard
L1 L4 L5 = FERRITE BEAD: EMIFIL (Inductor type) Chip Ferrite Bead BLM18A Series (0603 Size); Component Kind: Standard
L2 L24 = D-102-0002: Inductor, Ferrite Bead 1K@100MHz 300mA 0805 (2012) BLM21BB050SN1; Inductance: nH; Category: Inductor; Component Kind: Standard; Library Name: AltiumDesignLibrary.DesignLib; Max Input Current: 300 mA; Mounting Technology: Surface Mount; Status: Production
L3 = FERRITE BEAD: EMIFIL (Inductor type) Chip Ferrite Bead BMB2A Series (0603 Size); Component Kind: Standard
LED1 = D-153-0004: WL-TMRW LED, Green 3mm Waterclear without stopper; Brightness: 12.5 mcd; Peak Wave Length: 565 nm; Category: LED; Color: N/A; Component Kind: Standard; Library Name: AltiumDesignLibrary.DesignLib; Mounting Technology: Surface Mount; Status: Production
LED2 = D-153-0004: WL-TMRW LED, YELLOW 3mm Waterclear without stopper; Brightness: 12.5 mcd; Peak Wave Length: 565 nm; Category: LED; Color: N/A; Component Kind: Standard; Library Name: AltiumDesignLibrary.DesignLib; Mounting Technology: Surface Mount; Status: Production
LED3 = D-153-0004: WL-TMRW LED, RED 3mm Waterclear without stopper; Brightness: 12.5 mcd; Peak Wave Length: 565 nm; Category: LED; Color: N/A; Component Kind: Standard; Library Name: AltiumDesignLibrary.DesignLib; Mounting Technology: Surface Mount; Status: Production
LED4 = D-153-0003: WL-TMRW LED, Green 3mm Waterclear without stopper; Brightness: 17.0 mcd; Peak Wave Length: 639 nm; Category: LED; Color: N/A; Component Kind: Standard; Library Name: AltiumDesignLibrary.DesignLib; Mounting Technology: Surface Mount; Status: Production
R1 R55 R60 R65 R84-R86 R90 = Res2: YAGEO Chip Res 1/10W 1%; Component Kind: Standard; LatestRevisionDate: 17-Jul-2002; LatestRevisionNote: Re-released for DXP Platform.; Library Name: 3DGeneral.SCHLIB; PackageReference: AXIAL-0.4; Published: 8-Jun-2000; Signal Integrity: Res; Simulation: RESISTOR; Publisher: Altium Limited
R2-R5 R8 = RES2: YAGEO Chip Res 1/10W 1%; Component Kind: Standard
R6 R7 = Res2: Resistor; Component Kind: Standard; LatestRevisionDate: 17-Jul-2002; LatestRevisionNote: Re-released for DXP Platform.; Library Name: 3DGeneral.SCHLIB; PackageReference: AXIAL-0.4; Published: 8-Jun-2000; Signal Integrity: Res; Simulation: RESISTOR; Publisher: Altium Limited
R11-R26 R30 R32-R34 R37 R91 = RES2: Component Kind: Standard
R44 R47 = Res2: YAGEO Chip Res 1/16W 1%; Component Kind: Standard; LatestRevisionDate: 17-Jul-2002; LatestRevisionNote: Re-released for DXP Platform.; Library Name: 3DGeneral.SCHLIB; PackageReference: AXIAL-0.4; Published: 8-Jun-2000; Signal Integrity: Res; Simulation: RESISTOR; Publisher: Altium Limited
RA1 = RA: YAGEO  5%   1608x4  Resistor Array; Component Kind: Standard; Library Name: 3DGeneral.SCHLIB
RA2 RA4 = MNR34: YAGEO  5%   1608x4  Resistor Array; AEMS Code: 1300330; Component Kind: Standard; Library Name: 2015.10.1.SCHLIB
S1 = SW DIP-2: WS-TASV SMD Tact Switch; Component Kind: Standard; Library Name: 2017.4.24 MainBoard.SchLib
S2 = BMX2: Small Compact SMD Dip Swith; Component Kind: Standard; Library Name: 3DGeneral.SCHLIB
SD1 = SDCARD: Component Kind: Standard; Description: MicroSDCard; Library Name: 3DGeneral.SCHLIB
U1 = M25P32: 512 Kbit to 32 Mbit, Low Voltage, Serial Flash Memory With 40 MHz or 50 MHz SPI Bus Interface; Component Kind: Standard; Library Name: 3DGeneral.SCHLIB
U2 = STM32F429IGT6: ARM Cortex-M4 32b MCU+FPU, 210DMIPS, up to 1MB Flash/192+4KB RAM; Component Kind: Standard; Library Name: 2015.10.1.SCHLIB
U3 = M51957: VOLTAGE DETECTING, SYSTEM RESETTING IC SERIES; Component Kind: Standard; Library Name: 2015.10.1.SCHLIB
U4 = 24CXX: Two-wire Serial EEPROM; Component Kind: Standard; Library Name: Core-Board.SCHLIB
U5 U12 = IS42S16160B: 256MB Winbond SDRAM; Component Kind: Standard; Library Name: STM32F429_CORE.SCHLIB
Y1 = CRYSTAL_5032: SG5032CAN24.0MHZTJGA; Component Kind: Standard; Library Name: 2017.4.24 MainBoard.SchLib
Y2 = CRYSTAL: Component Kind: Standard; Description: Crystal; Library Name: 2015.10.1.SCHLIB
